# Altium schematic sheet main.SchDoc
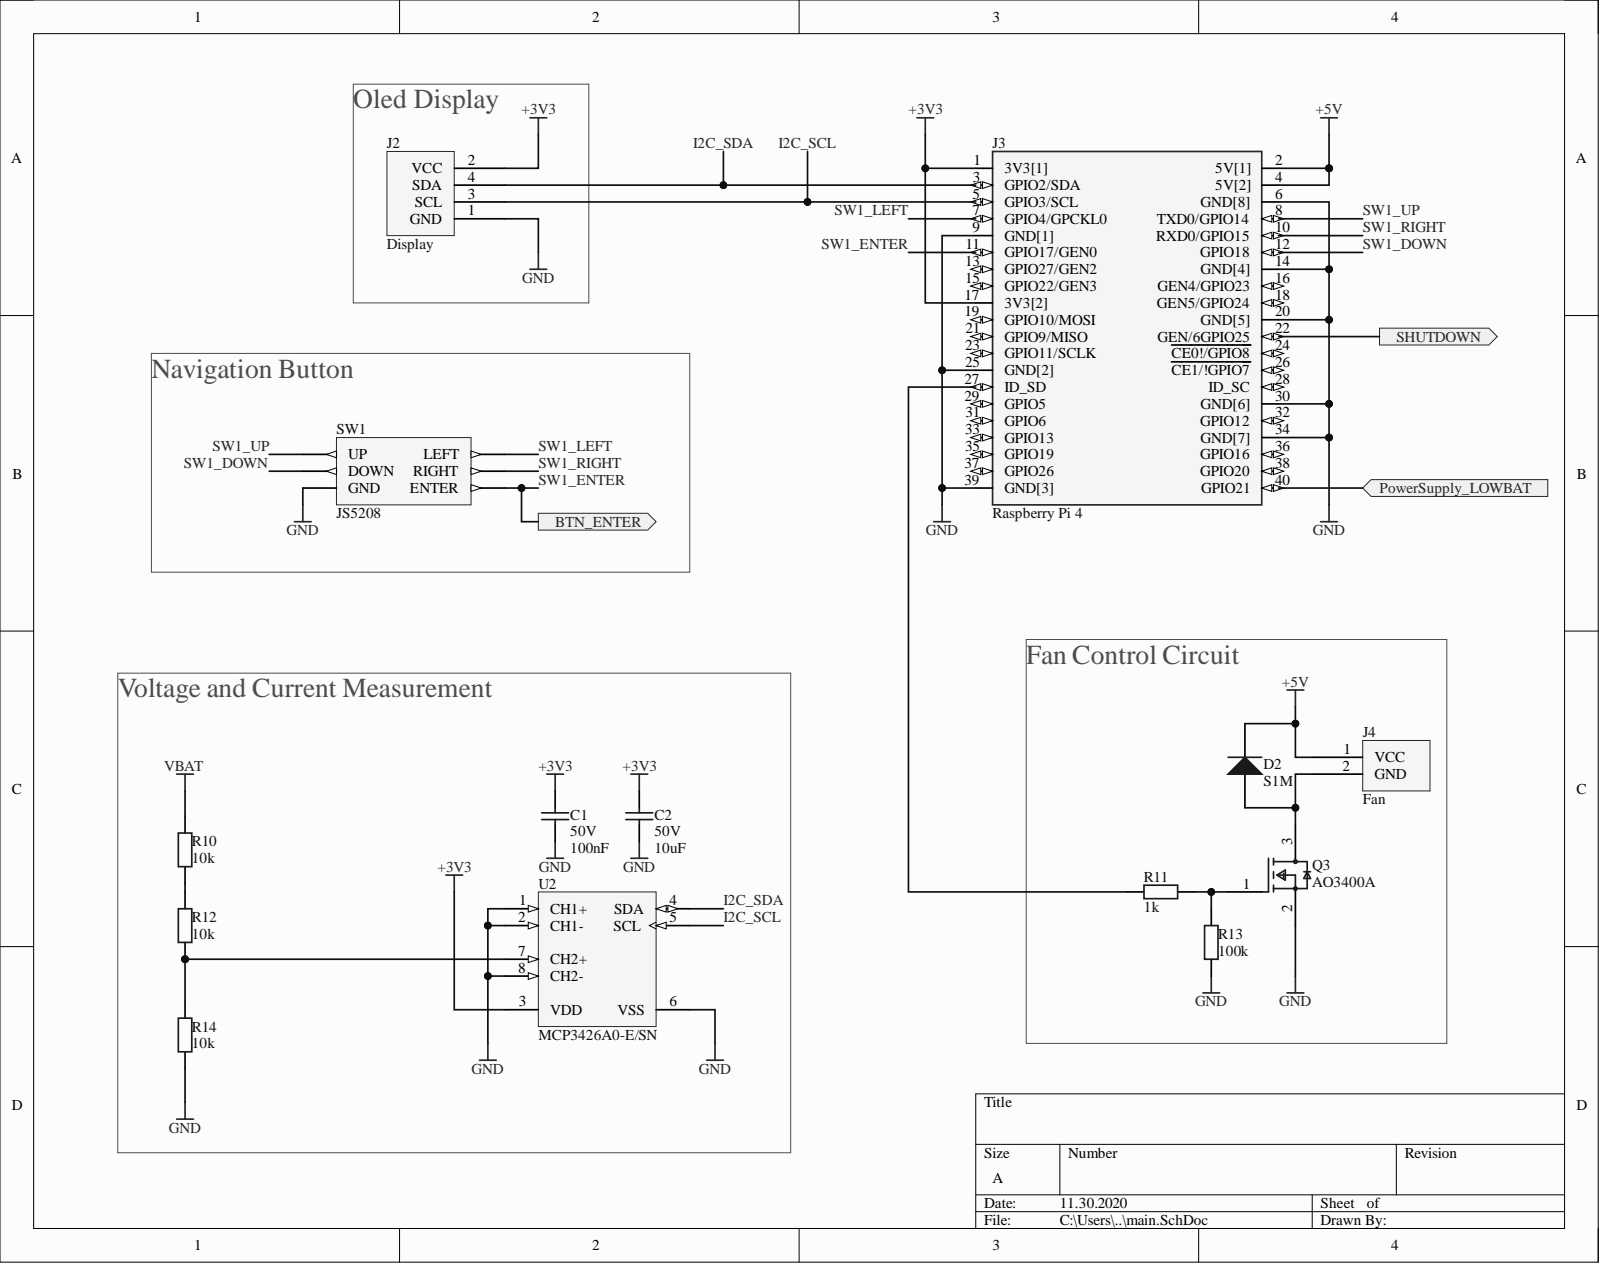
[diagram p0: main.SchDoc]

## Parts
C1  value 100nF  Voltage Rating: 50V  [CAPC2013X88X50NL20T25]  (hidden comment: Cap_0805)
C2  value 10uF  Voltage Rating: 50V  [CAPC2013X88X50NL20T25]  (hidden comment: Cap_0805)
D2  S1M  [FAIR-DO-214AC-2_V]
J2  Display  [OLED_DISPLAY]
J3  Raspberry Pi 4  MF: Raspberry Pi  [RASPBERRY_PI_4]
J4  Fan  [FAN_CONNECTOR]
Q3  AO3400A  [SOT23]
R10  value 10k  [RESC2013X50X30NL20T20]  (hidden comment: Res_0805)
R11  value 1k  [RESC2013X50X30NL20T20]  (hidden comment: Res_0805)
R12  value 10k  [RESC2013X50X30NL20T20]  (hidden comment: Res_0805)
R13  value 100k  [RESC2013X50X30NL20T20]  (hidden comment: Res_0805)
R14  value 10k  [RESC2013X50X30NL20T20]  (hidden comment: Res_0805)
SW1  JS5208  [JS5208_PKG]
U2  MCP3426A0-E/SN  [SOIC-SN8_L]

## Ports
BTN_ENTER, PowerSupply_LOWBAT, SHUTDOWN

## Nets
+3V3: C1.2 C2.2 J2.2(VCC) J3.1(3V3[1]) J3.17(3V3[2]) U2.3(VDD)
+5V: D2.2 J3.2(5V[1]) J3.4(5V[2]) J4.1
GND: C1.1 C2.1 J2.1(GND) J3.6(GND[8]) J3.9(GND[1]) J3.14(GND[4]) J3.20(GND[5]) J3.25(GND[2]) J3.30(GND[6]) J3.34(GND[7]) J3.39(GND[3]) Q3.2 R13.2 R14.1 SW1.COM(GND) U2.1(CH1+) U2.2(CH1-) U2.6(VSS) U2.8(CH2-)
VBAT: R10.2
I2C_SCL: J2.3(SCL) J3.5(GPIO3/SCL) U2.5(SCL)
I2C_SDA: J2.4(SDA) J3.3(GPIO2/SDA) U2.4(SDA)
PowerSupply_LOWBAT: J3.40(GPIO21) ; port PowerSupply_LOWBAT
SHUTDOWN: J3.22(GEN/6GPIO25) ; port SHUTDOWN
SW1_DOWN: J3.12(GPIO18) SW1.C-D(DOWN)
SW1_ENTER: J3.11(GPIO17/GEN0) SW1.E-BTN(ENTER) ; port BTN_ENTER
SW1_LEFT: J3.7(GPIO4/GPCKL0) SW1.B-L(LEFT)
SW1_RIGHT: J3.10(RXD0/GPIO15) SW1.D-R(RIGHT)
SW1_UP: J3.8(TXD0/GPIO14) SW1.A-U(UP)
NetD2_1: D2.1 J4.2 Q3.3
NetJ3_13: J3.13(GPIO27/GEN2)
NetJ3_15: J3.15(GPIO22/GEN3)
NetJ3_16: J3.16(GEN4/GPIO23)
NetJ3_18: J3.18(GEN5/GPIO24)
NetJ3_19: J3.19(GPIO10/MOSI)
NetJ3_21: J3.21(GPIO9/MISO)
NetJ3_23: J3.23(GPIO11/SCLK)
NetJ3_24: J3.24(CE0!/GPIO8)
NetJ3_26: J3.26(CE1/!GPIO7)
NetJ3_27: J3.27(ID_SD) R11.1
NetJ3_28: J3.28(ID_SC)
NetJ3_29: J3.29(GPIO5)
NetJ3_31: J3.31(GPIO6)
NetJ3_32: J3.32(GPIO12)
NetJ3_33: J3.33(GPIO13)
NetJ3_35: J3.35(GPIO19)
NetJ3_36: J3.36(GPIO16)
NetJ3_37: J3.37(GPIO26)
NetJ3_38: J3.38(GPIO20)
NetQ3_1: Q3.1 R11.2 R13.1
NetR10_1: R10.1 R12.2
NetR12_1: R12.1 R14.2 U2.7(CH2+)

## Library data of the parts
C1 C2 = Cap_0805: Ceramic Capacitor X7R; Component Kind: Standard; Signal Integrity: Cap; Simulation: CAP; Library Name: Biral_Generic.IntLib
D2 = CMP-2000-04942-2: General Purpose Rectifier, 1 A, 1000 V, -55 to 150 degC, 2-Pin DO-214AC, RoHS, Tape and Reel; Manufacturer: Fairchild; Current If @ Vf: 1A; Current Ifsm: 30A; Diode Configuration: Single; Diode Polarity: Cathode; Diode Type: StandardRecovery; External Depth: 2.79mm; External Length / Height: 2.44mm; External Width: 5.28mm; Forward Voltage: 1V; No. of Pins: 2; Reel Quantity: 7500; Repetitive Reverse Voltage Vrrm Max: 1kV; Reverse Recovery Time trr Max: 1.8µs; SMD Marking: 1M; SVHC: NoSVHC(19-Dec-2012); Alternate Case Style: DO-214AC; Component Kind: Standard; Datasheet URL: https://datasheet.ciiva.com/4452/s1m-191340-4452980.pdf; Datasheet Version: Rev. 1.1.2, 10/2013; Diode Case Style: DO-214AC; Forward Current If(AV): 1A; Forward Surge Current Ifsm Max: 30A; Forward Voltage VF Max: 1V; Junction Temperature Tj Max: 150degC; Library Name: Altium Content Vault; Manufacturer URL: https://www.fairchildsemi.com/; Operating Temperature Max: 150degC; Operating Temperature Min: -55degC; Operating Temperature Range: -55degCto+150degC; Package / Case: SMA; Package Description: 2-Pin Surface Mount Device, Body 4.375 x 2.725 mm; Package Reference: DO-214AC-2; Package URL: https://www.fairchildsemi.com/package-drawings/DO/DO214AC.pdf; Reverse Recovery Time trr Typ: 1.8µs; Tape Width: 12mm; Termination Type: SMD
J2 = Oled Display: Tiger Claw(TM) Socket Strip, Through-hole, Vertical, -55 to 105 degC, 2 mm Pitch, 4-Pin, Female, RoHS; Manufacturer: Samtec; PartNumber: MMS-104-01-T-SV; Pitch: 2 mm; Component Kind: Standard; ComponentLink1Description: Manufacturer URL; ComponentLink1URL: http://www.samtec.com; ComponentLink2Description: Datasheet; ComponentLink2URL: http://www.samtec.com/documents/webfiles/pdf/MMS.PDF; ComponentLink3Description: Package Specification; ComponentLink3URL: http://www.samtec.com/documents/webfiles/cpdf/MMS-1XX-01-XXX-XX-XXX-MKT1.pdf; DatasheetVersion: F-214; Library Name: Schlib.SchLib; Mounting Technology: Through-hole; Orientation: Vertical; PackageDescription: 2mm Through Hole Box Connector Strip Assembly; PackageReference: MMS-104-01-X-SV; PackageVersion: AO, 2/1993; RoHS: True; Temperature Range: -55 to 105 degC
J3 = RASPBERRY_PI4: Raspberry Pi 4 with Headers_; MP: ADA3708; Availability: Unavailable; Component Kind: Standard; Library Name: Schlib.SchLib; Package: None; Price: None; SnapEDA_Link: https://www.snapeda.com/parts/ADA3708/Raspberry%20Pi/view-part/2770118/?ref=snap
J4 = FAN: Tiger Claw(TM) Socket Strip, Through-hole, Vertical, -55 to 105 degC, 2 mm Pitch, 4-Pin, Female, RoHS; Manufacturer: Samtec; PartNumber: MMS-104-01-T-SV; Pitch: 2 mm; Component Kind: Standard; ComponentLink1Description: Manufacturer URL; ComponentLink1URL: http://www.samtec.com; ComponentLink2Description: Datasheet; ComponentLink2URL: http://www.samtec.com/documents/webfiles/pdf/MMS.PDF; ComponentLink3Description: Package Specification; ComponentLink3URL: http://www.samtec.com/documents/webfiles/cpdf/MMS-1XX-01-XXX-XX-XXX-MKT1.pdf; DatasheetVersion: F-214; Library Name: Schlib.SchLib; Mounting Technology: Through-hole; Orientation: Vertical; PackageDescription: 2mm Through Hole Box Connector Strip Assembly; PackageReference: MMS-104-01-X-SV; PackageVersion: AO, 2/1993; RoHS: True; Temperature Range: -55 to 105 degC
Q3 = MOSFET_AO3400A: MOSFET N-CH 30V 5.7A SOT23; Gate to Source Voltage (Vgs): 12V; Input Capacitance: 630pF; Max Power Dissipation: 1.4W; Number of Elements: 1; Rds On Max: 26.5mR; Case/Package: SOT-23; Component Kind: Standard; Continuous Drain Current (ID): 5.7A; Drain to Source Voltage (Vdss): 30V; Lead Free: Lead Free; Library Name: Schlib.SchLib; Max Operating Temperature: 150°C; Min Operating Temperature: -55°C; Mount: Surface Mount; Number of Pins: 3; Packaging: Tape and Reel; Power Dissipation: 1.4W; Radiation Hardening: No
R10-R14 = Res_0805: Resistor; Power Rating: 125mW; Tolerance: 1%; Component Kind: Standard; Signal Integrity: Res; Simulation: RESISTOR; Library Name: Biral_Generic.IntLib
SW1 = NAV_BUTTON: E-SWITCH - JS5208 - SWITCH, NAVIGATION, 5 POSITION, 20mA, SMD; Electrical Life: 100000Cycles; Operating Force: 500gf; Voltage Rating (DC): 15V; Category: Switches; Component Kind: Standard; ComponentLink1Description: https://datasheet.ciiva.com/1530/js5208-1530917.pdf?src-supplier=Element14; ComponentLink1URL: https://datasheet.ciiva.com/1530/js5208-1530917.pdf?src-supplier=Element14; ComponentLink2Description: https://datasheet.ciiva.com/12447/js5208-19824-12447191.pdf?src-supplier=Mouser; ComponentLink2URL: https://datasheet.ciiva.com/12447/js5208-19824-12447191.pdf?src-supplier=Mouser; ComponentLink3Description: https://datasheet.ciiva.com/2869/p200020c-2869289.pdf?src-supplier=Digi-Key; ComponentLink3URL: https://datasheet.ciiva.com/2869/p200020c-2869289.pdf?src-supplier=Digi-Key; ComponentLink4Description: https://datasheet.ciiva.com/16360/js5208-16360789.pdf?src-supplier=Future+Electronics; ComponentLink4URL: https://datasheet.ciiva.com/16360/js5208-16360789.pdf?src-supplier=Future+Electronics; Contact Material: Silver; Current Rating: 20mA; Lead Free: Lead Free; Library Name: Schlib.SchLib; Manufacturer: E-Switch; Manufacturer Part Number: JS5208; Max Operating Temperature: 70°C; Mechanical Life: 100000Cycles; Min Operating Temperature: -20°C; Mount: Surface Mount; Number of Pins: 5; Package Quantity: 300; Pricing 1: 1=2.49000, 5=2.43200, 10=2.04400, 25=1.93360, 50=1.82320, 100=1.65750 (USD); REACH SVHC: No SVHC; RoHS: Yes; Stock 1: 2460; Supplier 1: Digi-Key; Supplier Part Number 1: EG4561-ND; Termination: Gull Wing
U2 = CMP-0188-01151-1: 16-Bit, Multi-Channel Delta-Sigma Analog-to-Digital Converter with I2C Interface and On-Board Reference, 8-Pin SOIC 150mil, Extended Temperature; Code_IPC: SOIC127P600-8; Code_JEDEC: MS-012; Component Kind: Standard; ComponentLink1Description: Manufacturer URL; ComponentLink1URL: http://www.microchip.com/; ComponentLink2Description: Datasheet; ComponentLink2URL: http://ww1.microchip.com/downloads/en/DeviceDoc/22226a.pdf; ComponentLink3Description: Package Specification; ComponentLink3URL: http://www.microchip.com/stellent/groups/techpub_sg/documents/packagingspec/en012702.pdf; DatasheetVersion: revA, Dec-2009; Library Name: Altium Content Vault; PackageDescription: 8-Lead Plastic Small Outline (SN) - Narrow, 3.90mm Body [SOIC]; PackageReference: SOIC-SN8; PackageVersion: revBB, Aug-2009
